# Altium schematic sheet [03] - CONNECTORS.SchDoc("[03] - CONNECTORS")
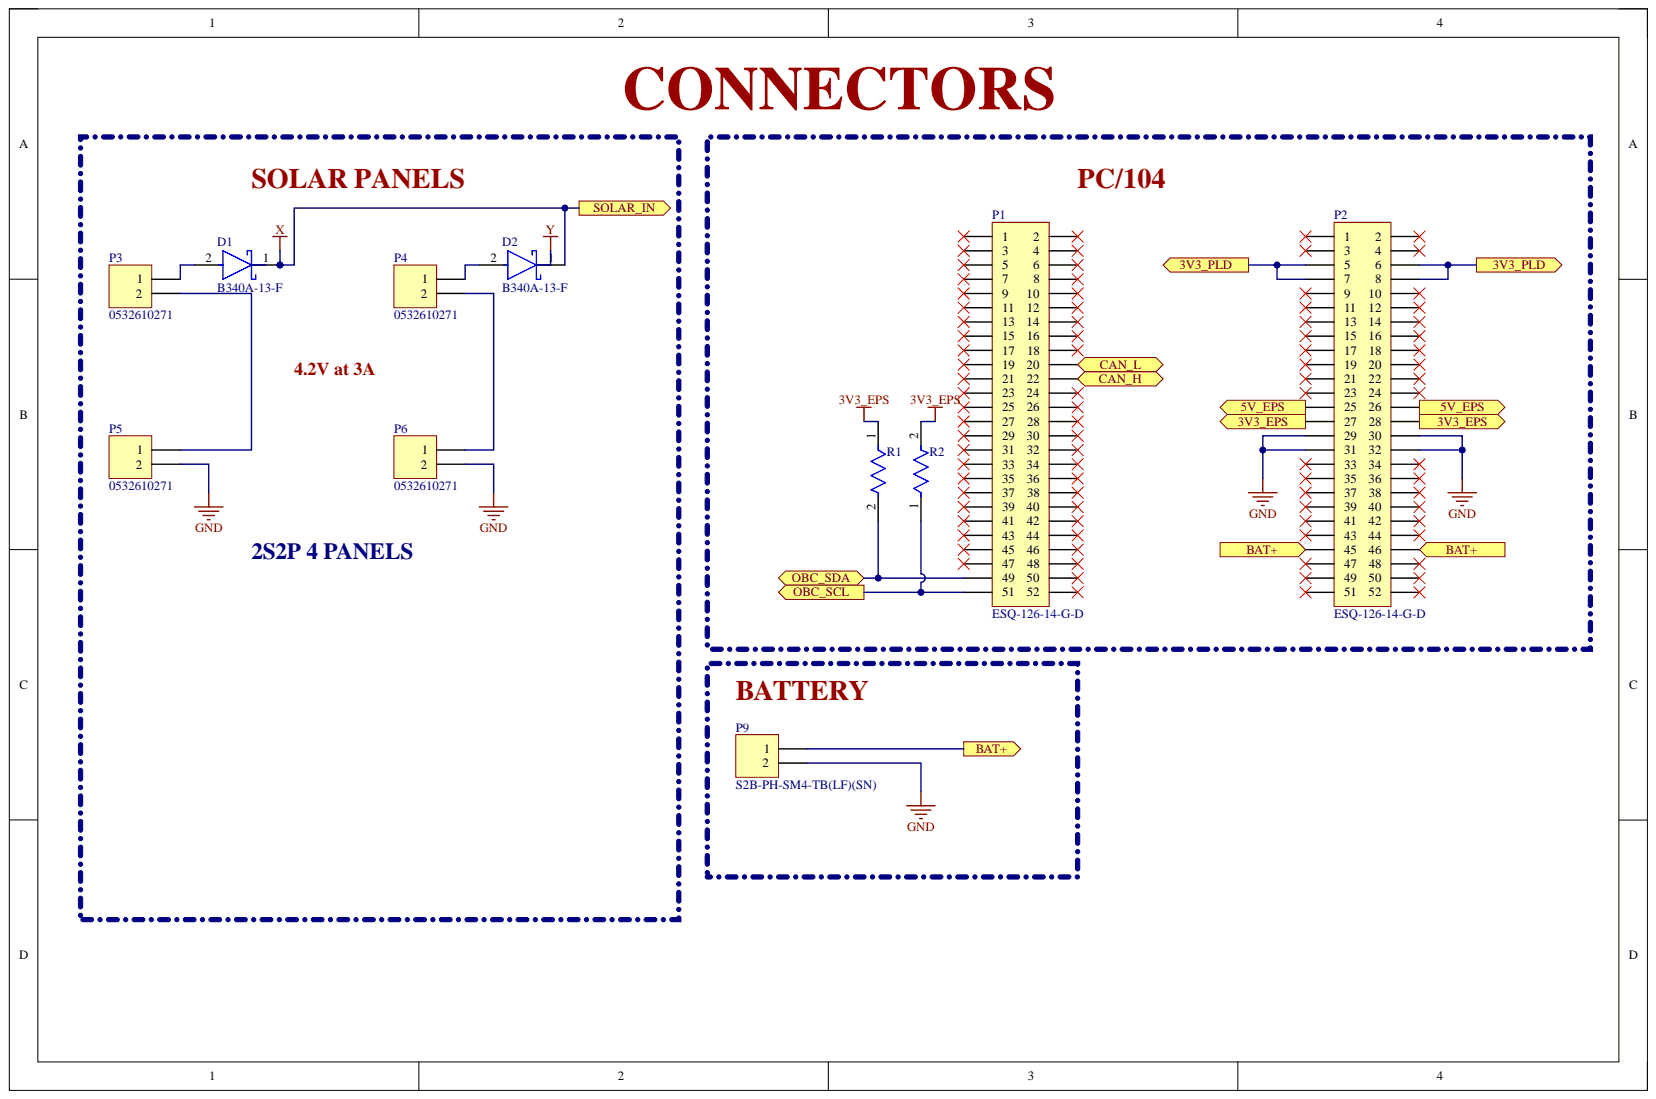
[diagram p0: [03] - CONNECTORS.SchDoc]

## Parts
D1  B340A-13-F  [FP-SMA-MFG]
D2  B340A-13-F  [FP-SMA-MFG]
P1  ESQ-126-14-G-D  [ESQ-126-14-G-D]
P2  ESQ-126-14-G-D  [ESQ-126-14-G-D]
P3  0532610271  [0532610271]
P4  0532610271  [0532610271]
P5  0532610271  [0532610271]
P6  0532610271  [0532610271]
P9  S2B-PH-SM4-TB(LF)(SN)  [S2B-PH-SM4-TB(LF)(SN)]
R1  AT0603DRE074K7L  [FP-AT0603-IPC_C]  (value not drawn)
R2  AT0603DRE074K7L  [FP-AT0603-IPC_C]  (value not drawn)

## Ports
3V3_EPS, 3V3_PLD, 5V_EPS, BAT+, CAN_H, CAN_L, OBC_SCL, OBC_SDA, SOLAR_IN

## Nets
3V3_EPS: R1.1 R2.2
GND: P2.29 P2.30 P2.31 P2.32 P5.2 P6.2 P9.2
3V3_PLD: P2.5 P2.6 P2.7 P2.8 ; port 3V3_PLD
5V_EPS: P2.25 P2.26 ; port 5V_EPS
BAT+: P2.45 P2.46 P9.1 ; port BAT+
CAN_H: P1.22 ; port CAN_H
CAN_L: P1.20 ; port CAN_L
OBC_SCL: P1.51 R2.1 ; port OBC_SCL
OBC_SDA: P1.49 R1.2 ; port OBC_SDA
X: D1.1 D2.1 ; port SOLAR_IN
NetD1_2: D1.2 P3.1
NetD2_2: D2.2 P4.1
NetP2_27: P2.27 P2.28 ; port 3V3_EPS
NetP3_2: P3.2 P5.1
NetP4_2: P4.2 P6.1
Y:

Not connected: P1 1 2 3 4 5 6 7 8 9 10 11 12 13 14 15 16 17 18 19 21 23 24 25 26 27 28 29 30 31 32 33 34 35 36 37 38 39 40 41 42 43 44 45 46 47 48 50 52; P2 1 2 3 4 9 10 11 12 13 14 15 16 17 18 19 20 21 22 23 24 33 34 35 36 37 38 39 40 41 42 43 44 47 48 49 50 51 52

## Library data of the parts
D1 D2 = CMP-1667-00003-3: DIODE SCHOTTKY 40V 3A SMA; Capacitance: 250pF; Element Configuration: Single; Forward Voltage: 500mV; Max Forward Surge Current (Ifsm): 80A; Max Reverse Current: 500uA; Polarity: Standard; Average Rectified Current: 3A; Case/Package: SMA; Component Kind: Standard; Contact Plating: Tin; Current: 3A; Current Rating: 3A; Forward Current: 3A; Height: 2.3mm; Lead Free: Lead Free; Length: 4.6mm; Library Name: Altium Content Vault; Max Operating Temperature: 125°C; Max Repetitive Reverse Voltage (Vrrm): 40V; Max Reverse Voltage (DC): 40V; Min Operating Temperature: -55°C; Mount: Surface Mount; Number of Pins: 2; Output Current: 3A; Package Quantity: 5000; Packaging: Tape and Reel; Peak Non-Repetitive Surge Current: 80A; Radiation Hardening: No; REACH SVHC: No SVHC; RoHS Compliant: Yes; Series: -; Termination: SMD/SMT; Voltage: 40V; Voltage Rating (DC): 40V; Weight: 0.002258oz; Width: 2.92mm
P1 P2 = ESQ-126-14-G-D: CONN SOCKET 52POS 0.1 GOLD PCB; Manufacturer: Samtec; Contact Resistance: 10mR; Gender: Receptacle; Max Current Rating: 6.9A; Max Voltage Rating (AC): 550V; Number of Contacts: 52; Pitch: 2.54mm; Component Kind: Standard; ComponentLink1Description: http://www.farnell.com/datasheets/1902536.pdf?src-supplier=Farnell; ComponentLink1URL: http://www.farnell.com/datasheets/1902536.pdf?src-supplier=Farnell; ComponentLink2Description: http://components.arrow.com/part/dataview/0323_04347871?src-supplier=Arrow+Europe; ComponentLink2URL: http://components.arrow.com/part/dataview/0323_04347871?src-supplier=Arrow+Europe; ComponentLink3Description: http://www.samtec.com/documents/webfiles/pdf/ESW_TH.PDF?src-supplier=Avnet+Europe; ComponentLink3URL: http://www.samtec.com/documents/webfiles/pdf/ESW_TH.PDF?src-supplier=Avnet+Europe; ComponentLink4Description: http://cloud.samtec.com/catalog_english/ESW_TH.PDF?src-supplier=Avnet; ComponentLink4URL: http://cloud.samtec.com/catalog_english/ESW_TH.PDF?src-supplier=Avnet; ComponentLink5Description: http://suddendocs.samtec.com/prints/esq-1xx-xx-x-x-xxx-xx-x-xx-mkt.pdf?src-supplier=Digi-Key; ComponentLink5URL: http://suddendocs.samtec.com/prints/esq-1xx-xx-x-x-xxx-xx-x-xx-mkt.pdf?src-supplier=Digi-Key; ComponentLink6Description: http://www.farnell.com/datasheets/1902536.pdf?src-supplier=Element14; ComponentLink6URL: http://www.farnell.com/datasheets/1902536.pdf?src-supplier=Element14; Contact Material: Bronze; Contact Plating: Gold; Depth: 4.95mm; Housing Color: Black; Housing Material: Polyester; Lead Pitch: 2.54mm; Length: 66.55mm; Manufacturer Part Number: ESQ-126-14-G-D; Max Operating Temperature: 125°C; Min Operating Temperature: -55°C; Number of Rows: 2; Orientation: Straight; Packaging: Bulk; Pricing 1: 1 = 9.64000, 10 = 8.91700, 100 = 7.47100, 500 = 6.26600, 1000 = 6.02500 (USD); Radiation Hardening: No; REACH SVHC: No SVHC; RoHS: Yes; Stock 1: 520; Supplier 1: Digi-Key; Supplier Part Number 1: SAM11114-ND; Termination: Solder; Library Name: UVG.IntLib
P3-P6 = 0532610271: CONN HEADER 2POS 1.25MM R/A SMD; Manufacturer: Molex; Manufacturer Part Number: 53261-0271; Contact Resistance: 20mR; Current Rating: 1A; Gender: Male; Glow Wire Compliant: Non-compliant; Insulation Resistance: 100MR; Number of Circuits: 2; Voltage Rating: 125V; Color: Beige; Component Kind: Standard; ComponentLink1Description: https://datasheet.ciiva.com/11747/0900766b8078fc90-11747475.pdf?src-supplier=RSComponents; ComponentLink1URL: https://datasheet.ciiva.com/11747/0900766b8078fc90-11747475.pdf?src-supplier=RSComponents; ComponentLink2Description: https://datasheet.ciiva.com/5151/0532610271-pcb-headers-171053-5151611.pdf?src-supplier=Mouser; ComponentLink2URL: https://datasheet.ciiva.com/5151/0532610271-pcb-headers-171053-5151611.pdf?src-supplier=Mouser; ComponentLink3Description: https://datasheet.ciiva.com/7167/1626147-7167496.pdf?src-supplier=Newark; ComponentLink3URL: https://datasheet.ciiva.com/7167/1626147-7167496.pdf?src-supplier=Newark; ComponentLink4Description: https://datasheet.ciiva.com/30193/198270532610271-pcb-headers-30193321.pdf?src-supplier=Onlinecomponents.com; ComponentLink4URL: https://datasheet.ciiva.com/30193/198270532610271-pcb-headers-30193321.pdf?src-supplier=Onlinecomponents.com; ComponentLink5Description: https://datasheet.ciiva.com/34303/1932547-34303342.pdf?src-supplier=Jameco; ComponentLink5URL: https://datasheet.ciiva.com/34303/1932547-34303342.pdf?src-supplier=Jameco; ComponentLink6Description: https://datasheet.ciiva.com/16658/0532610271-pcb-headers-16658423.pdf?src-supplier=Avnet+Europe; ComponentLink6URL: https://datasheet.ciiva.com/16658/0532610271-pcb-headers-16658423.pdf?src-supplier=Avnet+Europe; ComponentLink7Description: https://datasheet.ciiva.com/17035/70091115-17035863.pdf?src-supplier=Allied+Electronics; ComponentLink7URL: https://datasheet.ciiva.com/17035/70091115-17035863.pdf?src-supplier=Allied+Electronics; ComponentLink8Description: https://datasheet.ciiva.com/46393/1808526-46393547.pdf?src-supplier=Element14; ComponentLink8URL: https://datasheet.ciiva.com/46393/1808526-46393547.pdf?src-supplier=Element14; ComponentLink9Description: https://datasheet.ciiva.com/7920/1887027-7920826.pdf?src-supplier=Element14; ComponentLink9URL: https://datasheet.ciiva.com/7920/1887027-7920826.pdf?src-supplier=Element14; ComponentLink10Description: https://datasheet.ciiva.com/9468/0532610271-pcb-headers-9468506.pdf?src-supplier=Avnet; ComponentLink10URL: https://datasheet.ciiva.com/9468/0532610271-pcb-headers-9468506.pdf?src-supplier=Avnet; ComponentLink11Description: https://datasheet.ciiva.com/22683/1626147-22683562.pdf?src-supplier=Element14; ComponentLink11URL: https://datasheet.ciiva.com/22683/1626147-22683562.pdf?src-supplier=Element14; Contact Material: Bronze; Contact Plating: Tin; Flammability Rating: UL94 V-0; Housing Material: Thermoplastic; Lead Free: Lead Free; Lead Pitch: 1.25mm; Max Operating Temperature: 85°C; Min Operating Temperature: -40°C; Mount: Surface Mount; Number of Contacts: 2; Number of Positions: 2; Number of Rows: 1; Orientation: Right Angle; Package Quantity: 1000; Packaging: Tape and Reel; Pitch: 1.25mm; Pricing 1: 1 = 0.98000, 10 = 0.86000, 100 = 0.74170, 500 = 0.60688 (USD); Radiation Hardening: No; REACH SVHC: Unknown; RoHS: Yes; Stock 1: 34859; Supplier 1: Digi-Key; Supplier Part Number 1: WM7620CT-ND; Termination: SMD/SMT; Voltage Rating (AC): 125V; Voltage Rating (DC): 125V; Working Voltage: 125V; Library Name: UVG.IntLib
P9 = S2B-PH-SM4-TB(LF)(SN): CONN HEADER PH SIDE 2POS 2MM SMD; Manufacturer: JST; No of Positions: 2; Style/Orientation: Right Angle Header/Plug; Component Kind: Standard; ComponentLink1Description: https://datasheet.ciiva.com/11747/0900766b80df0d9d-11747104.pdf; ComponentLink1URL: https://datasheet.ciiva.com/11747/0900766b80df0d9d-11747104.pdf; ComponentLink2Description: https://datasheet.ciiva.com/712/eph-712514.pdf; ComponentLink2URL: https://datasheet.ciiva.com/712/eph-712514.pdf; ComponentLink3Description: https://datasheet.ciiva.com/712/eph-712515.pdf; ComponentLink3URL: https://datasheet.ciiva.com/712/eph-712515.pdf; ComponentLink4Description: https://datasheet.ciiva.com/712/eph-712516.pdf; ComponentLink4URL: https://datasheet.ciiva.com/712/eph-712516.pdf; ComponentLink5Description: https://datasheet.ciiva.com/16199/s2b-ph-sm4-tblfsn-16199686.pdf; ComponentLink5URL: https://datasheet.ciiva.com/16199/s2b-ph-sm4-tblfsn-16199686.pdf; ComponentLink6Description: https://datasheet.ciiva.com/26894/getdatasheetpartid-406256-26894907.pdf; ComponentLink6URL: https://datasheet.ciiva.com/26894/getdatasheetpartid-406256-26894907.pdf; Manufacturer Part Number: S2B-PH-SM4-TB(LF)(SN); Pitch: 2 mm; Pricing 1: 1=0.58, 10=0.547, 100=0.4194, 500=0.3647 (USD); Stock 1: 113530; Supplier 1: Digi-Key; Supplier Part Number 1: 455-1749-1-ND; Termination: Crimp; Type: Shrouded Header; Library Name: UVG.IntLib
R1 R2 = CMP-03412-017153-2: Thin Film Chip Resistors Automotive Grade 4.7kΩ 0.5% 50ppm/°C 0603; Max Power Dissipation: 100mW; Resistance: 4.7kR; Case/Package: 0603; Component Kind: Standard; Features: Moisture Resistant; Height: 0.022inch; Length: 0.063inch; Library Name: Altium Content Vault; Max Operating Temperature: 155°C; Min Operating Temperature: -55°C; Number of Terminations: 2; Packaging: Tape and Reel; Resistor Type: Thin Film; RoHS Compliant: Yes; Series: AT; Temperature Coefficient: 50ppm/°C; Tolerance: 0.5%; Width: 0.032inch
